# Altium schematic sheet Тензодатчик - Сервер TOP.SchDoc
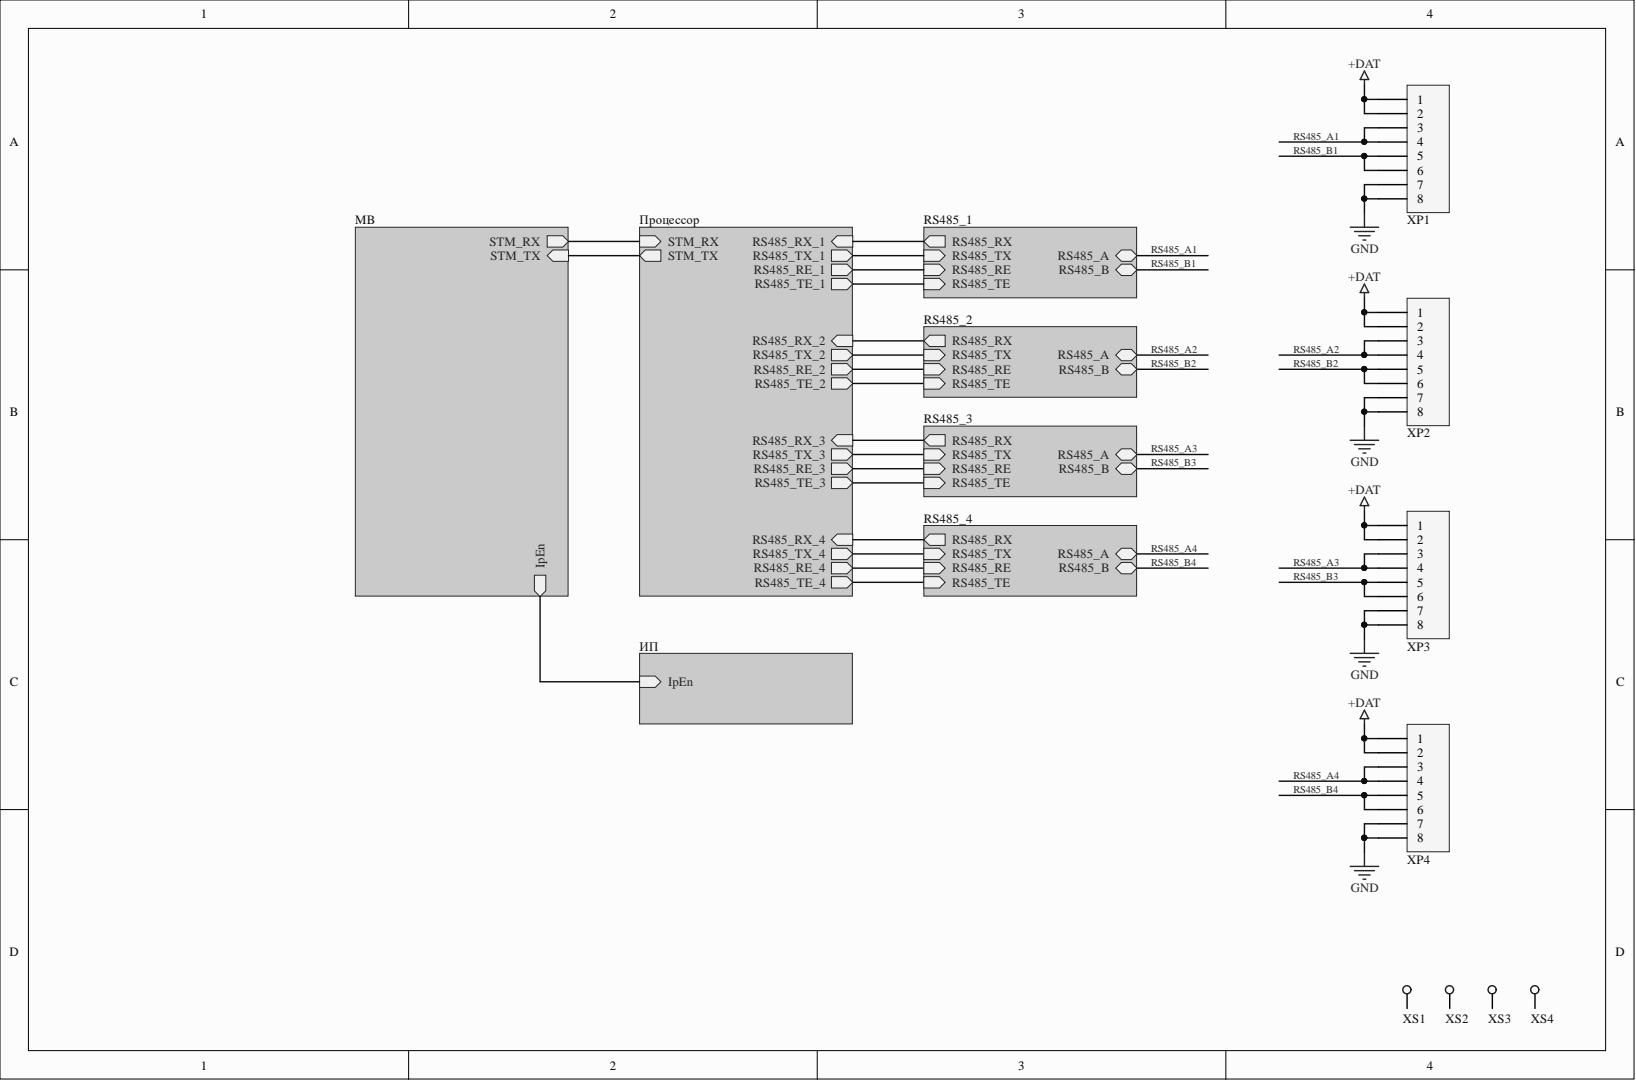
[diagram p0: Тензодатчик - Сервер TOP.SchDoc]

## Parts
XP1  TJ6-8p8c  [TJ6-8p8c]  (value not drawn)
XP2  TJ6-8p8c  [TJ6-8p8c]  (value not drawn)
XP3  TJ6-8p8c  [TJ6-8p8c]  (value not drawn)
XP4  TJ6-8p8c  [TJ6-8p8c]  (value not drawn)
XS1  PIN 3.5  [PIN 3.5]  (value not drawn)  (no BOM)
XS2  PIN 3.5  [PIN 3.5]  (value not drawn)  (no BOM)
XS3  PIN 3.5  [PIN 3.5]  (value not drawn)  (no BOM)
XS4  PIN 3.5  [PIN 3.5]  (value not drawn)  (no BOM)

## Nets
+DAT: XP1.1 XP1.2 XP2.1 XP2.2 XP3.1 XP3.2 XP4.1 XP4.2
GND: XP1.7 XP1.8 XP2.7 XP2.8 XP3.7 XP3.8 XP4.7 XP4.8
RS485_A1: XP1.3 XP1.4
RS485_A2: XP2.3 XP2.4
RS485_A3: XP3.3 XP3.4
RS485_A4: XP4.3 XP4.4
RS485_B1: XP1.5 XP1.6
RS485_B2: XP2.5 XP2.6
RS485_B3: XP3.5 XP3.6
RS485_B4: XP4.5 XP4.6
NetXS1_1: XS1.1
NetXS2_1: XS2.1
NetXS3_1: XS3.1
NetXS4_1: XS4.1
IpEn:
RS485_RE:
RS485_RX:
RS485_TE:
RS485_TX:
STM_RX:
STM_TX:

## Library data of the parts
XP1-XP4 = TJ6-8p8c: TJ6-8p8c (RJ45) розетка на плату; System: Connector; Component Kind: Standard; Library Name: Разьемы.SchLib
XS1-XS4 = PIN 3.5: Description: PIN 3.5; Library Name: Прочие.SchLib
